# Altium schematic sheet Neopixel Board.SchDoc
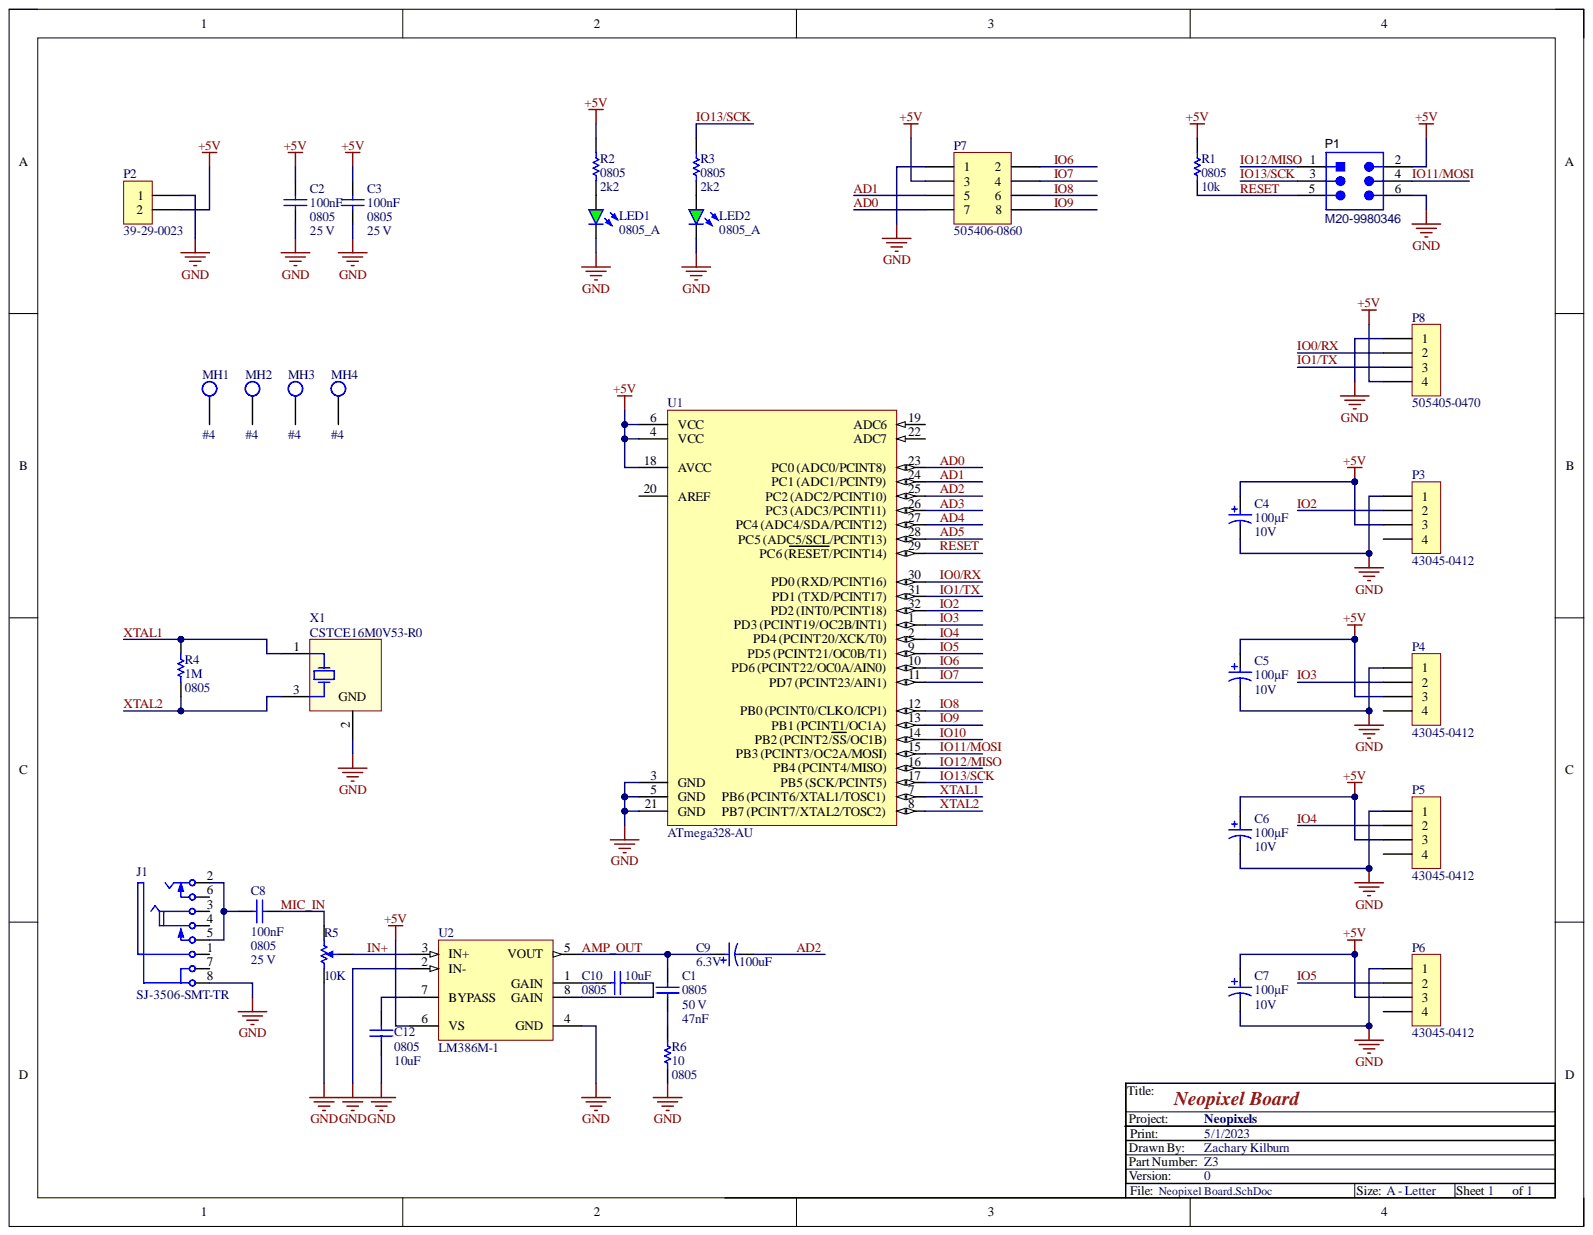
[diagram p0: Neopixel Board.SchDoc]

## Parts
C1  value 47nF  Case-EIA: 0805  Rated Voltage: 50 V  [CAPC0805(2012)100_N]  (hidden comment: CAP 47nF 50V 0805(2012))
C2  value 100nF  Case-EIA: 0805  [CAPC0805(2012)100_N]  (hidden comment: CAP 100nF 25V 0805(2012))
C3  value 100nF  Case-EIA: 0805  Rated Voltage: 25 V  [CAPC0805(2012)100_N]  (hidden comment: CAP 100nF 25V 0805(2012))
C4  value 100µF  Voltage: 10V  [PCC-KEMT-T495-D-RN]  (hidden comment: T495D107K010ATE100)
C5  value 100µF  Voltage: 10V  [PCC-KEMT-T495-D-RN]  (hidden comment: T495D107K010ATE100)
C6  value 100µF  Voltage: 10V  [PCC-KEMT-T495-D-RN]  (hidden comment: T495D107K010ATE100)
C7  value 100µF  Voltage: 10V  [PCC-KEMT-T495-D-RN]  (hidden comment: T495D107K010ATE100)
C8  value 100nF  Case-EIA: 0805  [CAPC0805(2012)100_N]  (hidden comment: CAP 100nF 25V 0805(2012))
C9  Capacitance: 100uF  Voltage: 6.3V  [PCC-XXX-CAP-ALUMSMD-B]  (hidden comment: CMP-001064-0)
C10  value 10uF  Case-EIA: 0805  [CAPC0805(2012)100_N]  (hidden comment: CAP 10uF 16V 0805(2012))
C12  value 10uF  Case-EIA: 0805  [CAPC0805(2012)100_N]  (hidden comment: CAP 10uF 16V 0805(2012))
J1  SJ-3506-SMT-TR  [CUI-SJ-3506-SMT-TR_V]
LED1  150080GS75000  [0805_A]  (value not drawn)
LED2  150080GS75000  [0805_A]  (value not drawn)
MH1  #4  [PCC-XXX-MH-#4-ROUND-PLATED-H128P230M290]  (no BOM)
MH2  #4  [PCC-XXX-MH-#4-ROUND-PLATED-H128P230M290]  (no BOM)
MH3  #4  [PCC-XXX-MH-#4-ROUND-PLATED-H128P230M290]  (no BOM)
MH4  #4  [PCC-XXX-MH-#4-ROUND-PLATED-H128P230M290]  (no BOM)
P1  M20-9980346  [HRWN-M20-9980346_V]
P2  39-29-0023  [PCC-MOLX-5566-VERT-02L-2X1-R]
P3  43045-0412  [PCC-MOLX-43045-0412-R]
P4  43045-0412  [PCC-MOLX-43045-0412-R]
P5  43045-0412  [PCC-MOLX-43045-0412-R]
P6  43045-0412  [PCC-MOLX-43045-0412-R]
P7  505406-0860  [PCC-MOLX-505406-0860-R]
P8  505405-0470  [PCC-MOLX-505405-0470-R]
R1  value 10k  Case-EIA: 0805  [RESC0805(2012)_N]  (hidden comment: 10K 5% 0805(2012))
R2  value 2k2  Case-EIA: 0805  [RESC0805(2012)_N]  (hidden comment: 2K2 5% 0805(2012))
R3  value 2k2  Case-EIA: 0805  [RESC0805(2012)_N]  (hidden comment: 2K2 5% 0805(2012))
R4  value 1M  Case-EIA: 0805  [RESC0805(2012)_N]  (hidden comment: 1M 5% 0805(2012))
R5  Resistance: 10K  [BOUR-TC33X-2_V]  (hidden comment: TC33X-2-103E)
R6  value 10  Case-EIA: 0805  [RESC0805(2012)_N]  (hidden comment: 10R 5% 0805(2012))
U1  ATmega328-AU  [32A_L]
U2  LM386M-1  [D0008A_M]
X1  CSTCE16M0V53-R0  [MURA-CSTCE_V-3_V]

## Nets
+5V: C2.2 C3.2 C4.1 C5.1 C6.1 C7.1 P1.2 P2.2 P3.3 P4.3 P5.3 P6.3 P7.3 P8.4 R1.2 R2.2 U1.4(VCC) U1.6(VCC) U1.18(AVCC) U2.6(VS)
GND: C2.1 C3.1 C4.2 C5.2 C6.2 C7.2 C12.2 J1.8 LED1.1 LED2.1 P1.6 P2.1 P3.1 P4.1 P5.1 P6.1 P7.1 P8.1 R5.1 R6.2 U1.3(GND) U1.5(GND) U1.21(GND) U2.2(IN-) U2.4(GND) X1.2
AD0: P7.7 U1.23(PC0_(ADC0/PCINT8))
AD1: P7.5 U1.24(PC1_(ADC1/PCINT9))
AD2: C9.2 U1.25
AD3: U1.26(PC3_(ADC3/PCINT11))
AD4: U1.27(PC4_(ADC4/SDA/PCINT12))
AD5: U1.28(PC5_(ADC5/SCL/PCINT13))
AMP_OUT: C1.2 C9.1 U2.5(VOUT)
IN+: R5.2 U2.3(IN+)
IO0/RX: P8.2 U1.30(PD0_(RXD/PCINT16))
IO1/TX: P8.3 U1.31(PD1_(TXD/PCINT17))
IO2: P3.2 U1.32(PD2_(INT0/PCINT18))
IO3: P4.2 U1.1(PD3_(PCINT19/OC2B/INT1))
IO4: P5.2 U1.2(PD4_(PCINT20/XCK/T0))
IO5: P6.2 U1.9(PD5_(PCINT21/OC0B/T1))
IO6: P7.2 U1.10(PD6_(PCINT22/OC0A/AIN0))
IO7: P7.4 U1.11(PD7_(PCINT23/AIN1))
IO8: P7.6 U1.12(PB0_(PCINT0/CLKO/ICP1))
IO9: P7.8 U1.13(PB1_(PCINT1/OC1A))
IO10: U1.14(PB2_(PCINT2/SS/OC1B))
IO11/MOSI: P1.4 U1.15(PB3_(PCINT3/OC2A/MOSI))
IO12/MISO: P1.1 U1.16(PB4_(PCINT4/MISO))
IO13/SCK: P1.3 R3.2 U1.17(PB5_(SCK/PCINT5))
MIC_IN: C8.1 R5.3
RESET: P1.5 R1.1 U1.29(PC6_(RESET/PCINT14))
XTAL1: R4.2 U1.7 X1.1
XTAL2: R4.1 U1.8 X1.3
NetC1_1: C1.1 R6.1
NetC8_2: C8.2 J1.2 J1.5
NetC10_1: C10.1 U2.8(GAIN)
NetC10_2: C10.2 U2.1(GAIN)
NetC12_1: C12.1 U2.7(BYPASS)
NetLED1_2: LED1.2 R2.1
NetLED2_2: LED2.2 R3.1

Not connected: J1 1 3 4 6 7; MH1 1; MH2 1; MH3 1; MH4 1; P3 4; P4 4; P5 4; P6 4; U1 19(ADC6) 20(AREF) 22(ADC7)

## Library data of the parts
C1 = CMP-1036-04256-1: CAP 47nF 50V ±5% 0805 (2012 Metric) Thickness 1mm SMD; Case-Metric: 2012; Tolerance: Â±5%; Component Kind: Standard; Library Name: Altium Content Vault; Max Thickness: 1 mm; Technology: SMT
C2 C3 C8 = CMP-1036-04409-1: CAP 100nF 25V ±5% 0805 (2012 Metric) Thickness 1mm SMD; Case-Metric: 2012; Tolerance: Â±5%; Component Kind: Standard; Library Name: Altium Content Vault; Max Thickness: 1 mm; Technology: SMT
  C2 C8: Rated Voltage: 25 V
C4-C7 = CMP-000480-0: Capacitor, Tantalum, 100µF, 10V, SMD, Case Code D; Manufacturer: Kemet; PackingType: Cut Tape; Component Kind: Standard; ComponentLink1Description: Manufacturer URL; ComponentLink1URL: http://www.kemet.com/; ComponentLink2Description: Product URL; ComponentLink2URL: http://www.kemet.com/Tantalum-MnO2; ComponentLink3Description: Datasheet; ComponentLink3URL: https://api.kemet.com/component-edge/download/datasheet/T495D107K010ATE100.pdf; ComponentLink4Description: Package Specification; ComponentLink4URL: https://api.kemet.com/component-edge/download/datasheet/T495D107K010ATE100.pdf; HelpURL: https://api.kemet.com/component-edge/download/datasheet/T495D107K010ATE100.pdf; Library Name: Integrated_Library - 02.08.19 - 15.59.SchLib; MountingTechnology: Surface Mount; OperatingTemperature: -55C to +125C; PackageName: D; PartNumber: T495D107K010ATE100; RoHS: Yes
C9 = CMP-001064-0: Capacitor, Aluminum Electrolytic, 100uF, 6.3V, SMD, Case Code B; Manufacturer: Panasonic; PartNumber: EEE-FT0J101AR; Component Kind: Standard; Library Name: Passives.SchLib; Supplier 1: Digi-Key; Supplier Part Number 1: P15076CT-ND
C10 C12 = CMP-1036-04928-1: CAP 10uF 16V ±10% 0805 (2012 Metric) Thickness 1mm SMD; Case-Metric: 2012; Tolerance: Â±10%; Component Kind: Standard; Library Name: Altium Content Vault; Max Thickness: 1 mm; Rated Voltage: 16 V; Technology: SMT
J1 = CMP-1692-00003-1: Female Mid Mount Audio Jack, 6 Position, Height 2.55 mm, Tail Length 2 mm, -25 to 85 degC, RoHS, Tape and Reel; Current Rating: 7 A; Impedance: 50 Ohm; Case/Package: Axial; Component Kind: Standard; ComponentLink1Description: Manufacturer URL; ComponentLink1URL: http://www.cui.com/; ComponentLink2Description: Datasheet; ComponentLink2URL: http://www.cui.com/product/resource/sj-3506-smt.pdf; DatasheetVersion: Rev. 1.01, 11/2012; Diameter: 3.6 mm; Height: 3.6 mm; Length: 5 mm; Library Name: Altium Content Vault; Max Operating Temperature: 85degC; Min Operating Temperature: -40degC; Mount: Through Hole; Package Quantity: 500; PackageDescription: Female Mid Mount Audio Jack, 6 Position, Height 2.55 mm, Tail Length 2 mm; PackageReference: SJ-3506-SMT-TR; Packaging: Bulk; Pins: 2; Radiation Hardening: No; REACH SVHC: No SVHC; RoHSCompliant: True; Width: 3.6 mm
LED1 LED2 = Green 0805: SMD mono-color Chip LED, WL-SMCW, Green; Manufacturer: Wuerth Elektronik; Color: Green; Component Kind: Standard; ComponentLink1Description: Manufacturer URL; ComponentLink1URL: http://www.we-online.com; ComponentLink2Description: Datasheet; ComponentLink2URL: http://katalog.we-online.com/led/datasheet/150080GS75000.pdf; Library Name: LEDs.SchLib; PackageReference: 0805_A; PartNumber: 150080GS75000
MH1-MH4 = CMP-000163-2: #4 Mounting Hole, Round, Plated, No Vias; Units: Imperial; Library Name: MountingHoleImperial.SchLib; Size: #4
P1 = 6 Pos Prog: Male Header, Pitch 2.54 mm, 2 x 3 Position, Height 8.64 mm, Tail Length 3 mm, -40 to 105 degC, RoHS, Bulk; Manufacturer: Harwin-Inc.; Connector Type: Header,Unshrouded,Breakaway; Number of Positions: 6; Number of Positions Loaded: All; Pitch: 0.100"(2.54mm); Category: Connectors,Interconnects; Color: Black; Component Kind: Standard; Contact Finish: Tin; Contact Finish Thickness: -; Contact Mating Length: 0.240"(6.10mm); Contact Type: MalePin; Datasheet URL: https://datasheet.ciiva.com/26899/getdatasheetpartid-483857-26899433.pdf; Datasheet Version: 12/2006; Family: RectangularConnectors-Headers,MalePins; Fastening Type: -; Features: -; Library Name: Headers.SchLib; Manufacturer URL: https://www.harwin.com/; Mounting Technology: ThroughHole; Mounting Type: ThroughHole; Number of Rows: 2; Package Description: Male Header, Pitch 2.54 mm, 2 x 3 Position, Height 8.64 mm, Tail Length 3 mm; Package Reference: M20-9980346; Packaging: Bulk; Row Spacing: 0.100"(2.54mm); Series: M20; Termination: Solder
P2 = CMP-000899-0: Molex Mini-Fit Jr. Connector, Dual-Row, 2-Position, Vertical, Gold Plating; Current: 9A; MatingStyle: Plug; Pitch: 4.2mm; Positions: 2; Voltage: 600V; Component Kind: Standard; ComponentLink1Description: Manufacturer URL; ComponentLink1URL: https://www.molex.com; ComponentLink2Description: Product URL; ComponentLink2URL: https://www.molex.com/molex/products/datasheet.jsp?part=active/0039290023_PCB_HEADERS.xml; ComponentLink3Description: Datasheet; ComponentLink3URL: https://www.molex.com/webdocs/datasheets/pdf/en-us/0039290023_PCB_HEADERS.pdf; ComponentLink4Description: Package Specification; ComponentLink4URL: https://www.molex.com/pdm_docs/sd/039290023_sd.pdf; HelpURL: https://www.molex.com/pdm_docs/sd/039290023_sd.pdf; Library Name: Connectors.SchLib; Manufacturer: Molex; MountingTechnology: Through Hole; Name: 39-29-0023; OperatingTemperature: Vertical; Orientation: -40C to +105C; PackageName: Mini-Fit Jr.; PartNumber: 39-29-0023; RoHS: Yes; Rows: 2; StorageTemperature: -40C to +105C
P3-P6 = CMP-000027-0: Molex Micro-Fit 3.0 Connector, Dual-Row, 4-Position, Vertical, 3mm Pitch; Current: 5A; MatingStyle: Plug; Positions: 4; Rows: 2; Voltage: 250V; Component Kind: Standard; ComponentLink1Description: Manufacturer URL; ComponentLink1URL: http://www.molex.com; ComponentLink2Description: Product URL; ComponentLink2URL: http://www.molex.com/molex/products/datasheet.jsp?part=active/0430450412_PCB_HEADERS.xml; ComponentLink3Description: Datasheet; ComponentLink3URL: http://www.molex.com/pdm_docs/ps/PS-43045.pdf; ComponentLink4Description: Package Specification; ComponentLink4URL: http://www.molex.com/pdm_docs/sd/430450412_sd.pdf; HelpURL: http://www.molex.com/pdm_docs/sd/430450412_sd.pdf; Library Name: Molex-Micro-Fit.SchLib; Manufacturer: Molex; MountingTechnology: Through Hole; OperatingTemperature: -40C to +105C; Orientation: Vertical; PackageName: Micro-Fit 3.0; PartNumber: 43045-0412; Pitch: 3mm; RoHS: Yes; StorageTemperature: -40C to +105C
P7 = CMP-000042-0: Molex CLIK-Mate Series Connector, Dual-Row, 8-Position, Vertical, 1.5mm Pitch; Current: 2A; MatingStyle: Plug; PackingType: Reel; Positions: 8; Rows: 2; Voltage: 100V; Component Kind: Standard; ComponentLink1Description: Manufacturer URL; ComponentLink1URL: http://www.molex.com; ComponentLink2Description: Product URL; ComponentLink2URL: http://www.molex.com/molex/products/datasheet.jsp?part=active/5054060860_PCB_RECEPTACLES.xml; ComponentLink3Description: Datasheet; ComponentLink3URL: http://www.molex.com/pdm_docs/ps/PS-505406-001.pdf; ComponentLink4Description: Package Specification; ComponentLink4URL: http://www.molex.com/pdm_docs/sd/5054060860_sd.pdf; HelpURL: http://www.molex.com/pdm_docs/sd/5054060860_sd.pdf; Library Name: Connectors.SchLib; Manufacturer: Molex; MountingTechnology: Surface Mount; OperatingTemperature: -40C to +105C; Orientation: Vertical; PackageName: CLIK-Mate; PartNumber: 505406-0860; Pitch: 1.5mm; RoHS: Yes; StorageTemperature: +5C to +35C
P8 = CMP-000031-0: Molex CLIK-Mate Series Connector, Single-Row, 4-Position, Vertical, 1.5mm Pitch; Current: 2A; MatingStyle: Plug; PackingType: Reel; Positions: 4; Rows: 1; Voltage: 100V; Component Kind: Standard; ComponentLink1Description: Manufacturer URL; ComponentLink1URL: http://www.molex.com; ComponentLink2Description: Product URL; ComponentLink2URL: http://www.molex.com/molex/products/datasheet.jsp?part=active/5054050470_PCB_RECEPTACLES.xml; ComponentLink3Description: Datasheet; ComponentLink3URL: http://www.molex.com/pdm_docs/ps/PS-505405-001.pdf; ComponentLink4Description: Package Specification; ComponentLink4URL: http://www.molex.com/pdm_docs/sd/5054050470_sd.pdf; HelpURL: http://www.molex.com/pdm_docs/sd/5054050470_sd.pdf; Library Name: Connectors.SchLib; Manufacturer: Molex; MountingTechnology: Surface Mount; OperatingTemperature: -40C to +105C; Orientation: Vertical; PackageName: CLIK-Mate; PartNumber: 505405-0470; Pitch: 1.5mm; RoHS: Yes; StorageTemperature: +5C to +35C
R1 = CMP-1013-00098-1: 10K 0.125W 5% 0805 (2012 Metric)  SMD; Case-Metric: 2012; Component Kind: Standard; Library Name: Altium Content Vault; Power: 0.125 W; Technology: SMT; Tolerance: 5 %
R2 R3 = CMP-1013-00082-1: 2K2 0.125W 5% 0805 (2012 Metric)  SMD; Case-Metric: 2012; Component Kind: Standard; Library Name: Altium Content Vault; Power: 0.125 W; Technology: SMT; Tolerance: 5 %
R4 = CMP-1013-00146-1: 1M 0.125W 5% 0805 (2012 Metric)  SMD; Case-Metric: 2012; Component Kind: Standard; Library Name: Altium Content Vault; Power: 0.125 W; Technology: SMT; Tolerance: 5 %
R5 = CMP-2100-03695-1: Trimming Potentiometer, 10000 Ohm, -40 to 100 degC, 3-Pin SMD, RoHS, Tape and Reel; Manufacturer: Bourns; Max Power Dissipation: 100mW; Number of Turns: 1; Temperature Coefficient: 250ppm/degreesC; Tolerance: 25%; Voltage Rating (DC): 50V; Case/Package: SMD/SMT; Colour: Silver; Component Kind: Standard; Composition: Potentiometer; Contact Plating: Silver; Datasheet URL: http://www.bourns.com/docs/Product-Datasheets/TC33.pdf; Datasheet Version: 42583.0; Depth: 3.8mm; Height: 0.047inch; Lead Free: LeadFree; Length: 3mm; Library Name: Altium Content Vault; Manufacturer URL: http://www.bourns.com/; Max Operating Temperature: 100degC; Military Standard: Not; Min Operating Temperature: -40degC; Mount: SurfaceMount; Package Description: 3-Pin Surface Mount Device, Body 3.8 x 3.6 mm; Package Quantity: 2500; Package Reference: TC33X-2; Packaging: TapeandReel; Power Rating: 100mW; Radiation Hardening: No; REACH SVHC: NoSVHC; RoHSCompliant: true; Taper: Linear; Termination: FootPrint; Weight: 29.4mg; Width: 0.118inch
R6 = CMP-1013-00026-1: 10R 0.125W 5% 0805 (2012 Metric)  SMD; Case-Metric: 2012; Component Kind: Standard; Library Name: Altium Content Vault; Power: 0.125 W; Technology: SMT; Tolerance: 5 %
U1 = ATmega328-AU: 8-bit AVR Microcontroller, 32KB Flash, 1KB EEPROM, 2KB SRAM, 32-pin TQFP, Industrial Grade (-40°C to 85°C); Code_JEDEC: MS-026-ABA; Component Kind: Standard; ComponentLink1Description: Manufacturer URL; ComponentLink1URL: http://www.atmel.com/; ComponentLink2Description: ATmega48A/48PA/88A/88PA/168A/168PA/328/328P Datasheet; ComponentLink2URL: http://www.atmel.com/dyn/resources/prod_documents/doc8271.pdf; ComponentLink3Description: ATmega48A/48PA/88A/88PA/168A/168PA/328/328P Summary; ComponentLink3URL: http://www.atmel.com/dyn/resources/prod_documents/8271S.pdf; DatasheetVersion: revD, May-2011; Library Name: Microcontrollers.SchLib; PackageDescription: 32-Lead, 7x7x1.0 mm Body, 0.8mm Lead Pitch, Thin Profile Plastic Quad Flat Package (TQFP); PackageReference: 32A; PackageVersion: revC, Oct-2010; RevisionNote: DatasheetVersion & PackageVersion updated. URLs added.
U2 = CMP-0057-00421-3: Low Voltage Audio Power Amplifier, 8-pin Narrow SOIC; Manufacturer: Texas Instruments; CompType: Audio IC; PackageTopMarking: NSZXTT*LM386*M-1; PowerWise: N; Code_IPC: SOIC127P600-8; Code_JEDEC: MS-012-AA; Component Kind: Standard; ComponentLink1Description: Datasheet URL; ComponentLink1URL: http://www.ti.com/general/docs/lit/getliterature.tsp?genericPartNumber=LM386&fileType=pdf; Library Name: Altium Content Vault; PackageDescription: SOIC Narrow, 4.9 x 3.9 x 1.45mm, 8 Lead, 1.27mm Pitch; PackageReference: D0008A; PinCount: 8; RoHS: N
X1 = 16MHz: Ceramic Resonator, 16 MHz with Built-in Capacitance, Thickness Expander Mode, Initial Frequency Tolerance +/- 0.5%, Load Capacity 15 pF, -20 to 80 degC, 3.2 x 1.3 x 1 mm SMD, RoHS, Reel; Manufacturer: Murata; Load Capacity: 15 pF; Oscillating Frequency: 16 MHz; Component Kind: Standard; ComponentLink1Description: Manufacturer URL; ComponentLink1URL: http://www.murata.com/; ComponentLink2Description: Datasheet; ComponentLink2URL: http://www.murata.com/products/catalog/pdf/p16e.pdf; DatasheetVersion: Rev. P16E-22; Initial Frequency Tolerance: +/- 0.5%; Initial Tolerance: +/- 0.5%; Library Name: Crystals.SchLib; Mounting Technology: Surface Mount; Operating Temperature Range: -20 to 80 degC; PackageDescription: 3-Pin SMD, Body 3.2 x 1.3 mm, Pitch 0.95 mm; PackageReference: CSTCE_V-3; Packing: Reel; PartNumber: CSTCE16M0V53-R0; RoHS: TRUE
